# Altium schematic sheet Audio.SchDoc(Audio) (continued)

## Library data of the parts
C6 = R82DC3220AA60K: Film Capacitor, 0.22 uF, 40 V, 63 V, ± 10%, Metallized PET Stacked, Radial Box - 2 Pin; Component Kind: Standard; Library Name: Fuzz_Pedal_Lib.SchLib
C7 C8 = R82EC2560SH60J: 0.056 µF Film Capacitor 63V 100V Polyester, Polyethylene Terephthalate (PET), Metallized - Stacked Radial; Manufacturer: Yageo Group; Dissipation Factor: 80%; Lead Diameter: 500µm; Tolerance: 5%; Type: General Purpose; Value: 56nF; Voltage Rating (AC): 40V; Case/Package: Radial; Category: Film Capacitors; Component Kind: Standard; ComponentLink1Description: https://datasheet.octopart.com:443/R82DC3220AA60K-KEMET-datasheet-182036371.pdf?src-supplier=IHS; ComponentLink1URL: https://datasheet.octopart.com:443/R82DC3220AA60K-KEMET-datasheet-182036371.pdf?src-supplier=IHS; ComponentLink2Description: https://datasheet.octopart.com:443/R82DC3220AA60K-KEMET-datasheet-83037517.pdf?src-supplier=Newark; ComponentLink2URL: https://datasheet.octopart.com:443/R82DC3220AA60K-KEMET-datasheet-83037517.pdf?src-supplier=Newark; ComponentLink3Description: https://datasheet.octopart.com:443/R82DC3220AA60K-KEMET-datasheet-176335909.pdf?src-supplier=Newark; ComponentLink3URL: https://datasheet.octopart.com:443/R82DC3220AA60K-KEMET-datasheet-176335909.pdf?src-supplier=Newark; ComponentLink4Description: https://datasheet.octopart.com:443/R82DC3220AA60K-KEMET-datasheet-137794307.pdf?src-supplier=Newark; ComponentLink4URL: https://datasheet.octopart.com:443/R82DC3220AA60K-KEMET-datasheet-137794307.pdf?src-supplier=Newark; ComponentLink5Description: https://datasheet.octopart.com:443/R82DC3220AA60K-KEMET-datasheet-181970268.pdf?src-supplier=Farnell; ComponentLink5URL: https://datasheet.octopart.com:443/R82DC3220AA60K-KEMET-datasheet-181970268.pdf?src-supplier=Farnell; ComponentLink6Description: https://datasheet.octopart.com:443/R82DC3220AA60K-KEMET-datasheet-98397505.pdf?src-supplier=element14+APAC; ComponentLink6URL: https://datasheet.octopart.com:443/R82DC3220AA60K-KEMET-datasheet-98397505.pdf?src-supplier=element14+APAC; ComponentLink7Description: https://datasheet.octopart.com:443/R82DC3220AA60K-KEMET-datasheet-86450218.pdf?src-supplier=element14+APAC; ComponentLink7URL: https://datasheet.octopart.com:443/R82DC3220AA60K-KEMET-datasheet-86450218.pdf?src-supplier=element14+APAC; ComponentLink8Description: https://datasheet.octopart.com:443/R82DC3220AA60K-KEMET-datasheet-189767587.pdf?src-supplier=ODG+(Origin+Data+Global); ComponentLink8URL: https://datasheet.octopart.com:443/R82DC3220AA60K-KEMET-datasheet-189767587.pdf?src-supplier=ODG+(Origin+Data+Global); ComponentLink9Description: https://datasheet.octopart.com:443/R82DC3220AA60K-KEMET-datasheet-157246254.pdf?src-supplier=JRH+Electronics; ComponentLink9URL: https://datasheet.octopart.com:443/R82DC3220AA60K-KEMET-datasheet-157246254.pdf?src-supplier=JRH+Electronics; ComponentLink10Description: https://datasheet.octopart.com:443/R82DC3220AA60K-KEMET-datasheet-117811300.pdf?src-supplier=TME; ComponentLink10URL: https://datasheet.octopart.com:443/R82DC3220AA60K-KEMET-datasheet-117811300.pdf?src-supplier=TME; ComponentLink11Description: https://datasheet.octopart.com:443/R82DC3220AA60K-KEMET-datasheet-62346237.pdf?src-supplier=TME; ComponentLink11URL: https://datasheet.octopart.com:443/R82DC3220AA60K-KEMET-datasheet-62346237.pdf?src-supplier=TME; ComponentLink12Description: http://sigma.octopart.com:80/conflict_mineral_statement/Yageo-company-3.pdf?src-supplier=Compliance+Statements; ComponentLink12URL: http://sigma.octopart.com:80/conflict_mineral_statement/Yageo-company-3.pdf?src-supplier=Compliance+Statements; ComponentLink13Description: http://sigma.octopart.com:80/rohs_statement/Yageo-company-2.pdf?src-supplier=Compliance+Statements; ComponentLink13URL: http://sigma.octopart.com:80/rohs_statement/Yageo-company-2.pdf?src-supplier=Compliance+Statements; Country of Origin: Mainland China, Taiwan; Depth: 2.5mm; Dielectric: PET; Dielectric Material: Polyester; Export Control Classification Number (ECCN) Code: EAR99; Harmonized Tariff Schedule (HTS) Code: 8532.25.00.70; Height: 6.5mm; Height - Seated (Max): 6.5024mm; Introduction Date: 2010-04-16; Lead Pitch: 5mm; Length: 7.2mm; Lifecycle Status: Production; Manufacturer Part Number: R82EC2560SH60J; Max Operating Temperature: 105°C; Min Operating Temperature: -55°C; Mounting Technology: Through Hole; Number of Terminals: 2; Packaging: Bulk; Pricing: 1=0.43, 10=0.262, 50=0.1946, 100=0.1738, 500=0.13882, 1000=0.12802 (USD); Radiation Hardening: No; Ratings: AEC-Q200; REACH SVHC: Unaffected; RoHS: Compliant; Stock: 3589; Supplier: DigiKey; Supplier Part Number: 399-R82EC2560SH60JCT-ND; Terminal Pitch: 5mm; Termination: Radial; Voltage Rating: 100V; Voltage Rating (DC): 100V; Width: 2.5mm; Library Name: Fuzz_Pedal_Lib.SchLib
C9 = UES1H330MPM1TD: Aluminum Electrolytic Capacitor, Non-polarized, Aluminum (wet), 50V, 20% +Tol, 20% -Tol, 33uF; Manufacturer: Nichicon; Life (Hours): 1000hours; Value: 33µF; Case/Package: Radial; Category: Aluminum Electrolytic Capacitors; China RoHS: Compliant; Component Kind: Standard; ComponentLink1Description: https://datasheet.octopart.com:443/UES1H330MPM1TD-Nichicon-datasheet-145428947.pdf?src-supplier=IHS; ComponentLink1URL: https://datasheet.octopart.com:443/UES1H330MPM1TD-Nichicon-datasheet-145428947.pdf?src-supplier=IHS; Country of Origin: Japan; Diameter: 10mm; Export Control Classification Number (ECCN) Code: EAR99; Harmonized Tariff Schedule (HTS) Code: 8532.22.00; Height - Seated (Max): 17.4752mm; Introduction Date: 2010-08-27; Lead Pitch: 5.0038mm; Length: 16mm; Lifecycle Status: Production; Manufacturer Part Number: UES1H330MPM1TD; Max Operating Temperature: 85°C; Min Operating Temperature: -40°C; Mounting Technology: Through Hole; Number of Terminals: 2; Pricing: 1=1.03, 10=0.655, 50=0.4964, 100=0.4462 (USD); REACH SVHC: Affected; RoHS: Compliant; Stock: 33; Supplier: DigiKey; Supplier Part Number: 493-10840-1-ND; Terminal Pitch: 5mm; Termination: Radial; Tolerance: 20%; Voltage Rating: 50V; Voltage Rating (DC): 50V; Library Name: Fuzz_Pedal_Lib.SchLib
C10 = UFW1V471MPD1TD: Radial Can ALUMINUM ELECTROLYTIC CAPACITOR UFW 35V 470muF ±20% 0.394Dia 10.00mm; Manufacturer: Nichicon; Leakage Current: 493.5µA; Life (Hours): 2000hours; Ripple Current: 630mA; Value: 470µF; Case/Package: Radial; Category: Aluminum Electrolytic Capacitors; China RoHS: Compliant; Component Kind: Standard; ComponentLink1Description: https://datasheet.octopart.com:443/UFW1V471MPD1TD-Nichicon-datasheet-137916677.pdf?src-supplier=IHS; ComponentLink1URL: https://datasheet.octopart.com:443/UFW1V471MPD1TD-Nichicon-datasheet-137916677.pdf?src-supplier=IHS; Diameter: 10mm; Height - Seated (Max): 17.4752mm; Lead Pitch: 5.0038mm; Length: 16mm; Lifecycle Status: Production; Manufacturer Part Number: UFW1V471MPD1TD; Max Operating Temperature: 85°C; Min Operating Temperature: -40°C; Mounting Technology: Through Hole; Number of Terminals: 2; Pricing: 1=0.89, 10=0.56, 50=0.4224, 100=0.3786 (USD); REACH SVHC: Affected; RoHS: Compliant; Schedule B: 8532220020, 8532220020|8532220020|8532220020|8532220020|8532220020; Stock: 110; Supplier: DigiKey; Supplier Part Number: 493-11045-1-ND; Terminal Pitch: 5mm; Tolerance: 20%; Voltage Rating: 35V; Voltage Rating (DC): 35V; Library Name: Fuzz_Pedal_Lib.SchLib
C11 = UFW1E221MPD1TD: Radial Can ALUMINUM ELECTROLYTIC CAPACITOR UFW 25V 220muF ±20% 0.315Dia 8.00mm; Manufacturer: Nichicon; Leakage Current: 165µA; Life (Hours): 2000hours; Ripple Current: 320mA; Value: 220µF; Case/Package: Radial; Category: Aluminum Electrolytic Capacitors; China RoHS: Compliant; Component Kind: Standard; ComponentLink1Description: https://datasheet.octopart.com:443/UFW1E221MPD1TD-Nichicon-datasheet-137916677.pdf?src-supplier=IHS; ComponentLink1URL: https://datasheet.octopart.com:443/UFW1E221MPD1TD-Nichicon-datasheet-137916677.pdf?src-supplier=IHS; ComponentLink2Description: https://datasheet.octopart.com:443/UFW1E221MPD1TD-Nichicon-datasheet-103276388.pdf?src-supplier=TME; ComponentLink2URL: https://datasheet.octopart.com:443/UFW1E221MPD1TD-Nichicon-datasheet-103276388.pdf?src-supplier=TME; Diameter: 8mm; Height - Seated (Max): 13.0048mm; Lead Pitch: 3.5052mm; Length: 11.5mm; Lifecycle Status: Production; Manufacturer Part Number: UFW1E221MPD1TD; Max Operating Temperature: 85°C; Min Operating Temperature: -40°C; Mounting Technology: Through Hole; Number of Terminals: 2; Pricing: 1000=0.11063, 2000=0.1011, 3000=0.09629, 5000=0.09091, 7000=0.08776, 10000=0.08471 (USD); REACH SVHC: Affected; RoHS: Compliant; Stock: 18000; Supplier: DigiKey; Supplier Part Number: 493-10992-3-ND; Terminal Pitch: 3.5mm; Tolerance: 20%; Voltage Rating: 25V; Voltage Rating (DC): 25V; Library Name: Fuzz_Pedal_Lib.SchLib
C12 = UCS2G100MPD1TD: Radial Can ALUMINUM ELECTROLYTIC CAPACITOR UCS 400V 10muF ±20% 0.394Dia 10.00mm; Manufacturer: KYOCERA AVX; Lead Diameter: 600µm; Leakage Current: 260µA; Life (Hours): 8000hours; Polarity: Polar; Ripple Current: 175mA; Value: 10µF; Case/Package: Radial; Category: Aluminum Electrolytic Capacitors; China RoHS: Compliant; Component Kind: Standard; ComponentLink1Description: https://datasheet.octopart.com:443/UCS2G100MPD1TD-AVX-datasheet-27608240.pdf?src-supplier=Upverter; ComponentLink1URL: https://datasheet.octopart.com:443/UCS2G100MPD1TD-AVX-datasheet-27608240.pdf?src-supplier=Upverter; ComponentLink2Description: https://datasheet.octopart.com:443/UCS2G100MPD1TD-Nichicon-datasheet-182099829.pdf?src-supplier=IHS; ComponentLink2URL: https://datasheet.octopart.com:443/UCS2G100MPD1TD-Nichicon-datasheet-182099829.pdf?src-supplier=IHS; ComponentLink3Description: https://datasheet.octopart.com:443/UCS2G100MPD1TD-Nichicon-datasheet-190027489.pdf?src-supplier=Farnell; ComponentLink3URL: https://datasheet.octopart.com:443/UCS2G100MPD1TD-Nichicon-datasheet-190027489.pdf?src-supplier=Farnell; ComponentLink4Description: https://datasheet.octopart.com:443/UCS2G100MPD1TD-Nichicon-datasheet-169250459.pdf?src-supplier=Future+Electronics; ComponentLink4URL: https://datasheet.octopart.com:443/UCS2G100MPD1TD-Nichicon-datasheet-169250459.pdf?src-supplier=Future+Electronics; ComponentLink5Description: https://datasheet.octopart.com:443/UCS2G100MPD1TD-Nichicon-datasheet-159781669.pdf?src-supplier=Future+Electronics; ComponentLink5URL: https://datasheet.octopart.com:443/UCS2G100MPD1TD-Nichicon-datasheet-159781669.pdf?src-supplier=Future+Electronics; ComponentLink6Description: https://datasheet.octopart.com:443/UCS2G100MPD1TD-Nichicon-datasheet-12523411.pdf?src-supplier=Future+Electronics; ComponentLink6URL: https://datasheet.octopart.com:443/UCS2G100MPD1TD-Nichicon-datasheet-12523411.pdf?src-supplier=Future+Electronics; ComponentLink7Description: https://datasheet.octopart.com:443/UCS2G100MPD1TD-Nichicon-datasheet-138083818.pdf?src-supplier=ODG+(Origin+Data+Global); ComponentLink7URL: https://datasheet.octopart.com:443/UCS2G100MPD1TD-Nichicon-datasheet-138083818.pdf?src-supplier=ODG+(Origin+Data+Global); ComponentLink8Description: https://datasheet.octopart.com:443/UCS2G100MPD1TD-Nichicon-datasheet-101168864.pdf?src-supplier=TME; ComponentLink8URL: https://datasheet.octopart.com:443/UCS2G100MPD1TD-Nichicon-datasheet-101168864.pdf?src-supplier=TME; ComponentLink9Description: https://datasheet.octopart.com:443/UCS2G100MPD1TD-Nichicon-datasheet-62308058.pdf?src-supplier=HQonline; ComponentLink9URL: https://datasheet.octopart.com:443/UCS2G100MPD1TD-Nichicon-datasheet-62308058.pdf?src-supplier=HQonline; ComponentLink10Description: http://sigma.octopart.com:80/conflict_mineral_statement/AVX-company-34.pdf?src-supplier=Compliance+Statements; ComponentLink10URL: http://sigma.octopart.com:80/conflict_mineral_statement/AVX-company-34.pdf?src-supplier=Compliance+Statements; ComponentLink11Description: http://sigma.octopart.com:80/reach_statement/AVX-company-19.pdf?src-supplier=Compliance+Statements; ComponentLink11URL: http://sigma.octopart.com:80/reach_statement/AVX-company-19.pdf?src-supplier=Compliance+Statements; Country of Origin: Japan; Diameter: 10mm; Export Control Classification Number (ECCN) Code: EAR99; Features: General Purpose; Harmonized Tariff Schedule (HTS) Code: 8532.22.00; Height - Seated (Max): 21.9964mm; Introduction Date: 2010-08-27; Lead Free: Lead Free; Lead Pitch: 5mm; Length: 20mm; Lifecycle Status: Production; Manufacturer Part Number: UCS2G100MPD1TD; Max Operating Temperature: 105°C; Min Operating Temperature: -40°C; Mounting Technology: Through Hole; Number of Terminals: 2; Pricing: 1=1.25, 10=0.797, 50=0.6072, 100=0.5471, 500=0.44366, 1000=0.41106, 1500=0.3946, 2500=0.37626, 3500=0.36547, 5000=0.35506 (USD); Radiation Hardening: No; REACH SVHC: Affected; RoHS: Compliant; Schedule B: 8532220020, 8532220020|8532220020|8532220020|8532220020|8532220020; Stock: 58653; Supplier: DigiKey; Supplier Part Number: 493-7383-1-ND; Terminal Pitch: 5mm; Termination: Radial; Tolerance: 20%; Voltage Rating: 400V; Voltage Rating (DC): 400V; Library Name: Fuzz_Pedal_Lib.SchLib
C13 C14 = C0603C104K5RACAUTO: Multilayer Ceramic Capacitors MLCC - SMD/SMT 50V 0.1uF X7R 0603 10% AEC-Q200; Manufacturer: Yageo Group; Dissipation Factor: 2.5%; Temperature Coefficient: 30ppm/°C; Value: 100nF; Case Code (Imperial): 0603; Case Code (Metric): 1608; Case/Package: 0603; Category: Ceramic Capacitors; China RoHS: Compliant; Component Kind: Standard; ComponentLink1Description: https://datasheet.octopart.com:443/C0603C104K5RACAUTO-KEMET-datasheet-178087915.pdf?src-supplier=Farnell; ComponentLink1URL: https://datasheet.octopart.com:443/C0603C104K5RACAUTO-KEMET-datasheet-178087915.pdf?src-supplier=Farnell; ComponentLink2Description: https://datasheet.octopart.com:443/C0603C104K5RACAUTO-KEMET-datasheet-140480346.pdf?src-supplier=Farnell; ComponentLink2URL: https://datasheet.octopart.com:443/C0603C104K5RACAUTO-KEMET-datasheet-140480346.pdf?src-supplier=Farnell; ComponentLink3Description: https://datasheet.octopart.com:443/C0603C104K5RACAUTO-KEMET-datasheet-138896278.pdf?src-supplier=Farnell; ComponentLink3URL: https://datasheet.octopart.com:443/C0603C104K5RACAUTO-KEMET-datasheet-138896278.pdf?src-supplier=Farnell; ComponentLink4Description: https://datasheet.octopart.com:443/C0603C104K5RACAUTO-KEMET-datasheet-101575653.pdf?src-supplier=Farnell; ComponentLink4URL: https://datasheet.octopart.com:443/C0603C104K5RACAUTO-KEMET-datasheet-101575653.pdf?src-supplier=Farnell; ComponentLink5Description: https://datasheet.octopart.com:443/C0603C104K5RACAUTO-KEMET-datasheet-169773475.pdf?src-supplier=Farnell; ComponentLink5URL: https://datasheet.octopart.com:443/C0603C104K5RACAUTO-KEMET-datasheet-169773475.pdf?src-supplier=Farnell; ComponentLink6Description: https://datasheet.octopart.com:443/C0603C104K5RAC-AUTO-Kemet-datasheet-11517047.pdf?src-supplier=Farnell; ComponentLink6URL: https://datasheet.octopart.com:443/C0603C104K5RAC-AUTO-Kemet-datasheet-11517047.pdf?src-supplier=Farnell; ComponentLink7Description: https://datasheet.octopart.com:443/C0603C104K5RACAUTO-KEMET-datasheet-137357764.pdf?src-supplier=Upverter; ComponentLink7URL: https://datasheet.octopart.com:443/C0603C104K5RACAUTO-KEMET-datasheet-137357764.pdf?src-supplier=Upverter; ComponentLink8Description: https://datasheet.octopart.com:443/C0603C104K5RACAUTO-Kemet-datasheet-17277841.pdf?src-supplier=Newark; ComponentLink8URL: https://datasheet.octopart.com:443/C0603C104K5RACAUTO-Kemet-datasheet-17277841.pdf?src-supplier=Newark; ComponentLink9Description: https://datasheet.octopart.com:443/C0603C104K5RAC-AUTO-Kemet-datasheet-10850653.pdf?src-supplier=Newark; ComponentLink9URL: https://datasheet.octopart.com:443/C0603C104K5RAC-AUTO-Kemet-datasheet-10850653.pdf?src-supplier=Newark; ComponentLink10Description: https://datasheet.octopart.com:443/C0603C104K5RACAUTO-KEMET-datasheet-176289396.pdf?src-supplier=JRH+Electronics; ComponentLink10URL: https://datasheet.octopart.com:443/C0603C104K5RACAUTO-KEMET-datasheet-176289396.pdf?src-supplier=JRH+Electronics; ComponentLink11Description: https://datasheet.octopart.com:443/C0603C104K5RACAUTO-KEMET-datasheet-153410967.pdf?src-supplier=Electro+Sonic; ComponentLink11URL: https://datasheet.octopart.com:443/C0603C104K5RACAUTO-KEMET-datasheet-153410967.pdf?src-supplier=Electro+Sonic; ComponentLink12Description: https://datasheet.octopart.com:443/C0603C104K5RACAUTO-KEMET-datasheet-142166320.pdf?src-supplier=Electro+Sonic; ComponentLink12URL: https://datasheet.octopart.com:443/C0603C104K5RACAUTO-KEMET-datasheet-142166320.pdf?src-supplier=Electro+Sonic; ComponentLink13Description: https://datasheet.octopart.com:443/C0603C104K5RACAUTO-Kemet-datasheet-66076775.pdf?src-supplier=element14+APAC; ComponentLink13URL: https://datasheet.octopart.com:443/C0603C104K5RACAUTO-Kemet-datasheet-66076775.pdf?src-supplier=element14+APAC; ComponentLink14Description: https://datasheet.octopart.com:443/C0603C104K5RACAUTO-Kemet-datasheet-66204749.pdf?src-supplier=element14+APAC; ComponentLink14URL: https://datasheet.octopart.com:443/C0603C104K5RACAUTO-Kemet-datasheet-66204749.pdf?src-supplier=element14+APAC; ComponentLink15Description: https://datasheet.octopart.com:443/C0603C104K5RACAUTO-Kemet-datasheet-66534724.pdf?src-supplier=element14+APAC; ComponentLink15URL: https://datasheet.octopart.com:443/C0603C104K5RACAUTO-Kemet-datasheet-66534724.pdf?src-supplier=element14+APAC; ComponentLink16Description: https://datasheet.octopart.com:443/C0603C104K5RACAUTO-KEMET-datasheet-83968784.pdf?src-supplier=TME; ComponentLink16URL: https://datasheet.octopart.com:443/C0603C104K5RACAUTO-KEMET-datasheet-83968784.pdf?src-supplier=TME; ComponentLink17Description: https://datasheet.octopart.com:443/C0603C104K5RACAUTO-KEMET-datasheet-83186888.pdf?src-supplier=TME; ComponentLink17URL: https://datasheet.octopart.com:443/C0603C104K5RACAUTO-KEMET-datasheet-83186888.pdf?src-supplier=TME; ComponentLink18Description: https://datasheet.octopart.com/C0603C104K5RACAUTO-KEMET-datasheet-84169754.pdf; ComponentLink18URL: https://datasheet.octopart.com/C0603C104K5RACAUTO-KEMET-datasheet-84169754.pdf; ComponentLink19Description: https://datasheet.octopart.com:443/C0603C104K5RACAUTO-KEMET-datasheet-189767472.pdf?src-supplier=ODG+(Origin+Data+Global); ComponentLink19URL: https://datasheet.octopart.com:443/C0603C104K5RACAUTO-KEMET-datasheet-189767472.pdf?src-supplier=ODG+(Origin+Data+Global); ComponentLink20Description: http://sigma.octopart.com:80/conflict_mineral_statement/Yageo-company-3.pdf?src-supplier=Compliance+Statements; ComponentLink20URL: http://sigma.octopart.com:80/conflict_mineral_statement/Yageo-company-3.pdf?src-supplier=Compliance+Statements; ComponentLink21Description: http://sigma.octopart.com:80/rohs_statement/Yageo-company-2.pdf?src-supplier=Compliance+Statements; ComponentLink21URL: http://sigma.octopart.com:80/rohs_statement/Yageo-company-2.pdf?src-supplier=Compliance+Statements; Contact Plating: Tin; Country of Origin: Mexico; Depth: 800µm; Dielectric: X7R; Dielectric Material: Ceramic; Export Control Classification Number (ECCN) Code: EAR99; Harmonized Tariff Schedule (HTS) Code: 8532.24.00.20; Height: 800µm; Introduction Date: 2011-07-27; Length: 1.6mm; Lifecycle Status: Production; Manufacturer Part Number: C0603C104K5RACAUTO; Material: Ceramic; Max Operating Temperature: 125°C; Min Operating Temperature: -55°C; Mounting Technology: Surface Mount; Number of Terminals: 2; Packaging: Cut Tape; Pricing: 1=0.15, 10=0.083, 50=0.0574, 100=0.0497, 500=0.0367 (USD); Radiation Hardening: No; Ratings: AEC-Q200; REACH SVHC: Yes; RoHS: Compliant; Schedule B: 8532240020, 8532240020|8532240020, 8532240020|8532240020|8532240020, 8532240020|8532240020|8532240020|8532240020; Stock: 1408607; Supplier: DigiKey; Supplier Part Number: 399-6856-6-ND; Termination: SMD/SMT; Thickness: 863.6µm; Tolerance: 10%; Voltage Rating: 50V; Voltage Rating (DC): 50V; Width: 800µm; Library Name: Tremolo_Pedal.IntLib
J2 J3 = RA49B11: Conn 1/4\" Phone Jack F 2 POS 7.62mm Solder RA Thru-Hole 4 Terminal 1 Port; Manufacturer: Switchcraft; Gender: Female; Number of Poles: 2; Number of Ports: 1; Shielding: Unshielded; Category: Connectors; Color: Black; Component Kind: Standard; ComponentLink1Description: https://datasheet.octopart.com:443/RA49B11-Switchcraft-datasheet-13685843.pdf?src-supplier=Master+Electronics; ComponentLink1URL: https://datasheet.octopart.com:443/RA49B11-Switchcraft-datasheet-13685843.pdf?src-supplier=Master+Electronics; ComponentLink2Description: https://datasheet.octopart.com:443/RA49B11-Switchcraft-datasheet-13537009.pdf?src-supplier=Sager+Electronics; ComponentLink2URL: https://datasheet.octopart.com:443/RA49B11-Switchcraft-datasheet-13537009.pdf?src-supplier=Sager+Electronics; ComponentLink3Description: https://datasheet.octopart.com:443/RA49B11-Switchcraft-datasheet-76366.pdf?src-supplier=Mouser; ComponentLink3URL: https://datasheet.octopart.com:443/RA49B11-Switchcraft-datasheet-76366.pdf?src-supplier=Mouser; Contact Plating: Silver; Country of Origin: USA; Depth: 34.03mm; Export Control Classification Number (ECCN) Code: EAR99; Harmonized Tariff Schedule (HTS) Code: 8536.69.40.10; Housing Color: Black; Housing Material: Thermoplastic; Introduction Date: 1997-12-01; Lead Free: Lead Free; Length: 15.74mm; Lifecycle Status: Production; Manufacturer Part Number: RA49B11; Mounting Technology: Through Hole; Number of Positions: 2; Number of Terminals: 4; Orientation: Right Angle; Pitch: 7.62mm; Pricing: 1=4.46, 10=3.793, 25=3.5556, 50=3.3856, 100=3.2239, 300=2.98343, 500=2.87784, 1000=2.74056, 2500=2.56916 (USD); REACH SVHC: Yes; RoHS: Compliant; Stock: 436; Supplier: DigiKey; Supplier Part Number: SC2546-ND; Termination: Solder; Library Name: Tremolo_Pedal.IntLib
Q1 Q2 = MMBT2222ALT1G: Bipolar (BJT) Transistor NPN 40V 600mA 300MHz 225mW Surface Mount SOT-23-3 Tape & Reel; Manufacturer: onsemi; Manufacturer Lifecycle Status: ACTIVE; Collector Base Voltage (VCBO): 75V; Collector Emitter Saturation Voltage: 300mV; Element Configuration: Single; Emitter Base Voltage (VEBO): 6V; hFE Min: 75; Max Junction Temperature (Tj): 150°C; Max Power Dissipation: 300mW; Number of Elements: 1; Type: General Purpose; Case/Package: SOT-23; Category: BJTs; China RoHS: Compliant; Clock Frequency: 300MHz; Collector Emitter Breakdown Voltage: 40V; Collector Emitter Voltage (VCEO): 40V; Component Kind: Standard; ComponentLink1Description: https://datasheet.octopart.com:443/MMBT2222ALT1G-ON-Semiconductor-datasheet-610557.pdf?src-supplier=onsemi; ComponentLink1URL: https://datasheet.octopart.com:443/MMBT2222ALT1G-ON-Semiconductor-datasheet-610557.pdf?src-supplier=onsemi; ComponentLink2Description: https://datasheet.octopart.com:443/MMBT2222ALT1G-ON-Semiconductor-datasheet-8326918.pdf?src-supplier=onsemi; ComponentLink2URL: https://datasheet.octopart.com:443/MMBT2222ALT1G-ON-Semiconductor-datasheet-8326918.pdf?src-supplier=onsemi; ComponentLink3Description: https://datasheet.octopart.com:443/MMBT2222ALT1G-onsemi-datasheet-180963556.pdf?src-supplier=IHS; ComponentLink3URL: https://datasheet.octopart.com:443/MMBT2222ALT1G-onsemi-datasheet-180963556.pdf?src-supplier=IHS; ComponentLink4Description: https://datasheet.octopart.com:443/MMBT2222ALT1G-ON-Semiconductor-datasheet-93401943.pdf?src-supplier=Upverter; ComponentLink4URL: https://datasheet.octopart.com:443/MMBT2222ALT1G-ON-Semiconductor-datasheet-93401943.pdf?src-supplier=Upverter; ComponentLink5Description: https://sigma.octopart.com:443/138897241/technical_drawing/ON-Semiconductor-MMBT2222ALT1G.pdf?src-supplier=Upverter; ComponentLink5URL: https://sigma.octopart.com:443/138897241/technical_drawing/ON-Semiconductor-MMBT2222ALT1G.pdf?src-supplier=Upverter; ComponentLink6Description: https://datasheet.octopart.com:443/MMBT2222ALT1G-ON-Semiconductor-datasheet-11598741.pdf?src-supplier=Farnell; ComponentLink6URL: https://datasheet.octopart.com:443/MMBT2222ALT1G-ON-Semiconductor-datasheet-11598741.pdf?src-supplier=Farnell; ComponentLink7Description: https://datasheet.octopart.com:443/MMBT2222ALT1G-ON-Semiconductor-datasheet-155100582.pdf?src-supplier=TME; ComponentLink7URL: https://datasheet.octopart.com:443/MMBT2222ALT1G-ON-Semiconductor-datasheet-155100582.pdf?src-supplier=TME; ComponentLink8Description: https://datasheet.octopart.com:443/MMBT2222ALT1G-ON-Semiconductor-datasheet-9666439.pdf?src-supplier=RS+(Formerly+Allied+Electronics); ComponentLink8URL: https://datasheet.octopart.com:443/MMBT2222ALT1G-ON-Semiconductor-datasheet-9666439.pdf?src-supplier=RS+(Formerly+Allied+Electronics); ComponentLink9Description: http://sigma.octopart.com:80/150618391/rohs_statement/ON-Semiconductor-MMBT2222ALT1G.pdf?src-supplier=Compliance+Statements; ComponentLink9URL: http://sigma.octopart.com:80/150618391/rohs_statement/ON-Semiconductor-MMBT2222ALT1G.pdf?src-supplier=Compliance+Statements; ComponentLink10Description: http://sigma.octopart.com:80/reach_statement/ON-Semiconductor-company-79.pdf?src-supplier=Compliance+Statements; ComponentLink10URL: http://sigma.octopart.com:80/reach_statement/ON-Semiconductor-company-79.pdf?src-supplier=Compliance+Statements; ComponentLink11Description: http://sigma.octopart.com:80/rohs_statement/Fairchild-Semiconductor-company-11.pdf?src-supplier=Compliance+Statements; ComponentLink11URL: http://sigma.octopart.com:80/rohs_statement/Fairchild-Semiconductor-company-11.pdf?src-supplier=Compliance+Statements; ComponentLink12Description: http://sigma.octopart.com:80/rohs_statement/Fairchild-Semiconductor-company-10.pdf?src-supplier=Compliance+Statements; ComponentLink12URL: http://sigma.octopart.com:80/rohs_statement/Fairchild-Semiconductor-company-10.pdf?src-supplier=Compliance+Statements; ComponentLink13Description: http://sigma.octopart.com:80/reach_statement/Fairchild-Semiconductor-company-9.pdf?src-supplier=Compliance+Statements; ComponentLink13URL: http://sigma.octopart.com:80/reach_statement/Fairchild-Semiconductor-company-9.pdf?src-supplier=Compliance+Statements; ComponentLink14Description: https://octopart.com:443/opatz8j6/c1?t=qUfD7E2yMa3Yk1vLXuv8OyRrgB35oCXT9-4lZ8hWbx63qVKY6CZ5Wx2VuO9N1oPnQ-OFoqvKrlbsAQTZvNQspaV8PzM0KMZrM5qimrAS2Zvqv1Jmjhq1XNHbtRnsNKP4QJNWwAWuXHzspahX0GPiJWYED5gCsLAWVM_Us9JHtNxAPP0IoypvAlSchXMw0lNpAwxWQgbKGZRbXI3egbgLrDMNwqXcjYqK5Lts70q_IXAZE63Sk2NOAQAaUe72vfA1BkFyWuN3Pafh36sNqvi0mkmDOT1QicIxslPPZmZplA9bng580C9Wj3PNCWJxQeY76huV7G-1Ts4qzJ3fZbIoJFL71Wq9dv0nuozXNA_jig8&src-supplier=Compliance+Statements; ComponentLink14URL: https://octopart.com:443/opatz8j6/c1?t=qUfD7E2yMa3Yk1vLXuv8OyRrgB35oCXT9-4lZ8hWbx63qVKY6CZ5Wx2VuO9N1oPnQ-OFoqvKrlbsAQTZvNQspaV8PzM0KMZrM5qimrAS2Zvqv1Jmjhq1XNHbtRnsNKP4QJNWwAWuXHzspahX0GPiJWYED5gCsLAWVM_Us9JHtNxAPP0IoypvAlSchXMw0lNpAwxWQgbKGZRbXI3egbgLrDMNwqXcjYqK5Lts70q_IXAZE63Sk2NOAQAaUe72vfA1BkFyWuN3Pafh36sNqvi0mkmDOT1QicIxslPPZmZplA9bng580C9Wj3PNCWJxQeY76huV7G-1Ts4qzJ3fZbIoJFL71Wq9dv0nuozXNA_jig8&src-supplier=Compliance+Statements; ComponentLink15Description: https://octopart.com:443/opatz8j6/c1?t=kIt2DkC0MAyf1TCB62HN4xrKGgEtOl4LXqimdH22cZ_WyAC3wC3E4Hdpe_0KznFcpnPTUsRM23Gl7aUqdnmdck66MDx_v__wJydKmihBqdbws59vCRNJfil0wkQBEwEF0_4QxDPd5IBZVfNlTKO6LnTTXAfkmbX-sPSl7uFpYsmiM-Sa7nH5TCfNiTPGpSB6kRuHhRRQlxAWjs174o_s8ub9WNbbjmqKG85Y2S3lbzJ_5XqEIWEtZ9AAJuebf_DLORk6e_Bzf-XCemQihTbyzJJFMLlrj4SBSEfiYkGJOKdEc8sNzlylH9ez8k9mXm3ufCPCTItPmmbs2xTNOrcgSFHxJ3mw6Y0m7fPrd-6i5Jlh&src-supplier=Compliance+Statements; ComponentLink15URL: https://octopart.com:443/opatz8j6/c1?t=kIt2DkC0MAyf1TCB62HN4xrKGgEtOl4LXqimdH22cZ_WyAC3wC3E4Hdpe_0KznFcpnPTUsRM23Gl7aUqdnmdck66MDx_v__wJydKmihBqdbws59vCRNJfil0wkQBEwEF0_4QxDPd5IBZVfNlTKO6LnTTXAfkmbX-sPSl7uFpYsmiM-Sa7nH5TCfNiTPGpSB6kRuHhRRQlxAWjs174o_s8ub9WNbbjmqKG85Y2S3lbzJ_5XqEIWEtZ9AAJuebf_DLORk6e_Bzf-XCemQihTbyzJJFMLlrj4SBSEfiYkGJOKdEc8sNzlylH9ez8k9mXm3ufCPCTItPmmbs2xTNOrcgSFHxJ3mw6Y0m7fPrd-6i5Jlh&src-supplier=Compliance+Statements; ComponentLink16Description: https://octopart.com:443/opatz8j6/c1?t=RVBw5Uc_Pazp42tUvaXS935cT8-DxpHWeVIh4jXHYy4FesJzPPCIcMiqYsNP7NqI3m7N8TKf8Albb342jbgVNXmkWnUz6gHgxAhyOhAnnoxGWZ5PI8dlUF9cAioFHqWVOV_D_N8fBmxKyk3z8i0FyerI2MquCKm8Ln-q5fn8BS6QCo5pr23rcU5tsu1QR4Af6HD8BmMSt_8GMuyCwA2NkrD9fIVaHy3VtBAKDxaMVO6y7u7LpPWHctH9hE20XcPiOAaUdi-rW2B7fXh7GTWiQLP3gRv8gfdHIShjsrlWD-oME1xcy5ic1bClPT5U4eHZ9MSIKaRqPzisKfm__r5FQX-RXRc0UcKzTW2wqRtUdpg&src-supplier=Compliance+Statements; ComponentLink16URL: https://octopart.com:443/opatz8j6/c1?t=RVBw5Uc_Pazp42tUvaXS935cT8-DxpHWeVIh4jXHYy4FesJzPPCIcMiqYsNP7NqI3m7N8TKf8Albb342jbgVNXmkWnUz6gHgxAhyOhAnnoxGWZ5PI8dlUF9cAioFHqWVOV_D_N8fBmxKyk3z8i0FyerI2MquCKm8Ln-q5fn8BS6QCo5pr23rcU5tsu1QR4Af6HD8BmMSt_8GMuyCwA2NkrD9fIVaHy3VtBAKDxaMVO6y7u7LpPWHctH9hE20XcPiOAaUdi-rW2B7fXh7GTWiQLP3gRv8gfdHIShjsrlWD-oME1xcy5ic1bClPT5U4eHZ9MSIKaRqPzisKfm__r5FQX-RXRc0UcKzTW2wqRtUdpg&src-supplier=Compliance+Statements; Contact Plating: Tin; Country of Origin: Mainland China; Current Rating: 600mA; Export Control Classification Number (ECCN) Code: EAR99; Frequency: 300MHz; Gain Bandwidth Product: 300MHz; Height: 1.11mm; Introduction Date: 1999-01-01; Lead Free: Lead Free; Length: 2.9mm; Lifecycle Status: Production; Manufacturer Part Number: MMBT2222ALT1G; Max Breakdown Voltage: 40V; Max Collector Current: 600mA; Max Operating Temperature: 150°C; Min Operating Temperature: -55°C; Number of Terminals: 3; Packaging: Tape and Reel; Pins: 3; Polarity: NPN; Power Dissipation: 225mW; Pricing: 1=0.15, 10=0.091, 100=0.0559, 500=0.04042, 1000=0.03538 (USD); Radiation Hardening: No; REACH SVHC: Unaffected; RoHS: Compliant; Schedule B: 8541210080, 8541210080|8541210080|8541210080|8541210080|8541210080; Stock: 2015515; Supplier: DigiKey; Supplier Part Number: MMBT2222ALT1GOSDKR-ND; Transition Frequency: 300MHz; Voltage Rating (DC): 40V; Width: 1.3mm; Library Name: Tremolo_Pedal.IntLib
R5 = CRCW0805909RFKEA: Res Thick Film 0805 909 Ohm 1% 1/8W ±100ppm/°C Molded SMD SMD Paper T/R; Manufacturer: Vishay; Manufacturer Package Identifier: CRCW0805-e3; Max Power Dissipation: 125mW; Value: 909Ω; Voltage Rating: 150V; Case Code (Imperial): 0805; Case/Package: 0805; Category: Chip SMD Resistors; China RoHS: Non-Compliant; Component Kind: Standard; ComponentLink1Description: https://datasheet.octopart.com:443/CRCW0805909RFKEA-Vishay-datasheet-175423584.pdf?src-supplier=IHS; ComponentLink1URL: https://datasheet.octopart.com:443/CRCW0805909RFKEA-Vishay-datasheet-175423584.pdf?src-supplier=IHS; ComponentLink2Description: https://datasheet.octopart.com:443/CRCW0805909RFKEA-Vishay-datasheet-66077789.pdf?src-supplier=element14+APAC; ComponentLink2URL: https://datasheet.octopart.com:443/CRCW0805909RFKEA-Vishay-datasheet-66077789.pdf?src-supplier=element14+APAC; ComponentLink3Description: https://datasheet.octopart.com:443/CRCW0805909RFKEA-Vishay-datasheet-62317937.pdf?src-supplier=element14+APAC; ComponentLink3URL: https://datasheet.octopart.com:443/CRCW0805909RFKEA-Vishay-datasheet-62317937.pdf?src-supplier=element14+APAC; ComponentLink4Description: https://datasheet.octopart.com:443/CRCW0805909RFKEA.-Vishay-datasheet-83961561.pdf?src-supplier=element14+APAC; ComponentLink4URL: https://datasheet.octopart.com:443/CRCW0805909RFKEA.-Vishay-datasheet-83961561.pdf?src-supplier=element14+APAC; ComponentLink5Description: https://datasheet.octopart.com:443/CRCW0805909RFKEA.-Vishay-datasheet-78776209.pdf?src-supplier=element14+APAC; ComponentLink5URL: https://datasheet.octopart.com:443/CRCW0805909RFKEA.-Vishay-datasheet-78776209.pdf?src-supplier=element14+APAC; ComponentLink6Description: https://datasheet.octopart.com:443/CRCW0805909RFKEA-Vishay-datasheet-14722531.pdf?src-supplier=element14+APAC; ComponentLink6URL: https://datasheet.octopart.com:443/CRCW0805909RFKEA-Vishay-datasheet-14722531.pdf?src-supplier=element14+APAC; ComponentLink7Description: https://datasheet.octopart.com:443/CRCW0805909RFKEA-Vishay-datasheet-5299586.pdf?src-supplier=element14+APAC; ComponentLink7URL: https://datasheet.octopart.com:443/CRCW0805909RFKEA-Vishay-datasheet-5299586.pdf?src-supplier=element14+APAC; ComponentLink8Description: https://datasheet.octopart.com:443/CRCW0805909RFKEA-Vishay-datasheet-10380026.pdf?src-supplier=element14+APAC; ComponentLink8URL: https://datasheet.octopart.com:443/CRCW0805909RFKEA-Vishay-datasheet-10380026.pdf?src-supplier=element14+APAC; ComponentLink9Description: https://datasheet.octopart.com:443/CRCW0805909RFKEA-Vishay-datasheet-11761042.pdf?src-supplier=Newark; ComponentLink9URL: https://datasheet.octopart.com:443/CRCW0805909RFKEA-Vishay-datasheet-11761042.pdf?src-supplier=Newark; ComponentLink10Description: https://datasheet.octopart.com:443/CRCW0805909RFKEA-Vishay-datasheet-11516975.pdf?src-supplier=Newark; ComponentLink10URL: https://datasheet.octopart.com:443/CRCW0805909RFKEA-Vishay-datasheet-11516975.pdf?src-supplier=Newark; ComponentLink11Description: https://datasheet.octopart.com:443/CRCW0805909RFKEA-Vishay-datasheet-159614168.pdf?src-supplier=Newark; ComponentLink11URL: https://datasheet.octopart.com:443/CRCW0805909RFKEA-Vishay-datasheet-159614168.pdf?src-supplier=Newark; ComponentLink12Description: https://datasheet.octopart.com:443/CRCW0805909RFKEA-Vishay-datasheet-41302739.pdf?src-supplier=Newark; ComponentLink12URL: https://datasheet.octopart.com:443/CRCW0805909RFKEA-Vishay-datasheet-41302739.pdf?src-supplier=Newark; ComponentLink13Description: https://datasheet.octopart.com:443/CRCW0805909RFKEA-Vishay-datasheet-190005712.pdf?src-supplier=TME; ComponentLink13URL: https://datasheet.octopart.com:443/CRCW0805909RFKEA-Vishay-datasheet-190005712.pdf?src-supplier=TME; ComponentLink14Description: https://datasheet.octopart.com:443/CRCW0805909RFKEA-Vishay-datasheet-137115326.pdf?src-supplier=X-ON; ComponentLink14URL: https://datasheet.octopart.com:443/CRCW0805909RFKEA-Vishay-datasheet-137115326.pdf?src-supplier=X-ON; ComponentLink15Description: https://datasheet.octopart.com:443/CRCW0805909RFKEA-Vishay-datasheet-62316122.pdf?src-supplier=Future+Electronics; ComponentLink15URL: https://datasheet.octopart.com:443/CRCW0805909RFKEA-Vishay-datasheet-62316122.pdf?src-supplier=Future+Electronics; ComponentLink16Description: https://datasheet.octopart.com:443/CRCW0805909RFKEA-Vishay-datasheet-5300368.pdf?src-supplier=Future+Electronics; ComponentLink16URL: https://datasheet.octopart.com:443/CRCW0805909RFKEA-Vishay-datasheet-5300368.pdf?src-supplier=Future+Electronics; ComponentLink17Description: https://datasheet.octopart.com:443/CRCW0805909RFKEA-Vishay-Dale-datasheet-47688880.pdf?src-supplier=RS+(Formerly+Allied+Electronics); ComponentLink17URL: https://datasheet.octopart.com:443/CRCW0805909RFKEA-Vishay-Dale-datasheet-47688880.pdf?src-supplier=RS+(Formerly+Allied+Electronics); ComponentLink18Description: https://datasheet.octopart.com:443/CRCW0805909RFKEA-Vishay-datasheet-12001583.pdf?src-supplier=RS+(Formerly+Allied+Electronics); ComponentLink18URL: https://datasheet.octopart.com:443/CRCW0805909RFKEA-Vishay-datasheet-12001583.pdf?src-supplier=RS+(Formerly+Allied+Electronics); ComponentLink19Description: https://datasheet.octopart.com:443/CRCW0805909RFKEA-Vishay-datasheet-5306405.pdf?src-supplier=RS+(Formerly+Allied+Electronics); ComponentLink19URL: https://datasheet.octopart.com:443/CRCW0805909RFKEA-Vishay-datasheet-5306405.pdf?src-supplier=RS+(Formerly+Allied+Electronics); ComponentLink20Description: https://datasheet.octopart.com/D-CRCW-e3-Standard-Thick-Film-Chip-Resistors-Vishay-datasheet-family-64.pdf; ComponentLink20URL: https://datasheet.octopart.com/D-CRCW-e3-Standard-Thick-Film-Chip-Resistors-Vishay-datasheet-family-64.pdf; ComponentLink21Description: https://datasheet.octopart.com:443/CRCW0805909RFKEA-Vishay-datasheet-8445837.pdf?src-supplier=element14; ComponentLink21URL: https://datasheet.octopart.com:443/CRCW0805909RFKEA-Vishay-datasheet-8445837.pdf?src-supplier=element14; ComponentLink22Description: https://datasheet.octopart.com:443/CRCW0805909RFKEA-Vishay-datasheet-5377306.pdf?src-supplier=Garrett; ComponentLink22URL: https://datasheet.octopart.com:443/CRCW0805909RFKEA-Vishay-datasheet-5377306.pdf?src-supplier=Garrett; ComponentLink23Description: https://datasheet.octopart.com:443/CRCW0805909RFKEA-Vishay-datasheet-148188.pdf?src-supplier=Garrett; ComponentLink23URL: https://datasheet.octopart.com:443/CRCW0805909RFKEA-Vishay-datasheet-148188.pdf?src-supplier=Garrett; ComponentLink24Description: https://datasheet.octopart.com:443/CRCW0805909RFKEA-Vishay-datasheet-34203.pdf?src-supplier=Garrett; ComponentLink24URL: https://datasheet.octopart.com:443/CRCW0805909RFKEA-Vishay-datasheet-34203.pdf?src-supplier=Garrett; ComponentLink25Description: http://sigma.octopart.com:80/rohs_certificate/D-CRCW-e3-Standard-Thick-Film-Chip-Resistors-Vishay-family-102.pdf?src-supplier=Compliance+Statements; ComponentLink25URL: http://sigma.octopart.com:80/rohs_certificate/D-CRCW-e3-Standard-Thick-Film-Chip-Resistors-Vishay-family-102.pdf?src-supplier=Compliance+Statements; Composition: Thick Film; Contact Plating: Tin; Country of Origin: Israel; Depth: 1.25mm; Export Control Classification Number (ECCN) Code: EAR99; Features: Automotive AEC-Q200; Harmonized Tariff Schedule (HTS) Code: 8533.21.00.30; Height: 450µm; Height - Seated (Max): 508µm; Introduction Date: 2005-02-16; Lead Free: Lead Free; Length: 2mm; Lifecycle Status: Production; Manufacturer Part Number: CRCW0805909RFKEA; Max Operating Temperature: 155°C; Military Standard: Not; Min Operating Temperature: -55°C; Mounting Technology: Surface Mount; Number of Terminals: 2; Number of Terminations: 2; Pins: 2; Power Rating: 125mW; Pricing: 1=0.1, 10=0.044, 25=0.0332, 50=0.0272, 100=0.0225, 250=0.01796, 500=0.01534, 1000=0.01329 (USD); Radiation Hardening: No; Ratings: AEC-Q200; REACH SVHC: Unaffected; RoHS: Compliant; Schedule B: 8533210045, 8533210045|8533210045|8533210045|8533210045|8533210045|8533210045|8533210045|8533210045|8533210045|8533210045|8533210045|8533210045|8533210045|8533210045|8533210045|8533210045|8533210045|8533210045|8533210045|8533210045|8533210045|8533210045|8533210045|85; Stock: 25445; Supplier: DigiKey; Supplier Part Number: 541-909CCT-ND; Temperature Coefficient: 100ppm/°C; Termination: SMD/SMT; Tolerance: 1%; Voltage Rating (DC): 150V; Weight: 5.499807mg; Width: 1.25mm; Working Voltage: 150V; Library Name: Fuzz_Pedal_Lib.SchLib
R6 R9 R13 = RC0805FR-071ML: 125mW Thick Film Resistors 150V ±100ppm/℃ ±1% 1MΩ 0805 Chip Resistor - Surface Mount ROHS; Manufacturer: Yageo Group; Temperature Coefficient: 100ppm/°C; Case Code (Imperial): 0805; Case/Package: 0805; Category: Chip SMD Resistors; China RoHS: Non-Compliant; Component Kind: Standard; ComponentLink1Description: https://datasheet.octopart.com:443/RC0805FR-071ML-Yageo-datasheet-175887523.pdf?src-supplier=IHS; ComponentLink1URL: https://datasheet.octopart.com:443/RC0805FR-071ML-Yageo-datasheet-175887523.pdf?src-supplier=IHS; ComponentLink2Description: https://datasheet.octopart.com:443/RC0805FR-071ML-Yageo-datasheet-103092701.pdf?src-supplier=Upverter; ComponentLink2URL: https://datasheet.octopart.com:443/RC0805FR-071ML-Yageo-datasheet-103092701.pdf?src-supplier=Upverter; ComponentLink3Description: https://datasheet.octopart.com:443/RC0805FR-071ML-Yageo-datasheet-79472498.pdf?src-supplier=Upverter; ComponentLink3URL: https://datasheet.octopart.com:443/RC0805FR-071ML-Yageo-datasheet-79472498.pdf?src-supplier=Upverter; ComponentLink4Description: https://datasheet.octopart.com:443/RC0805FR-071ML-Yageo-datasheet-8330313.pdf?src-supplier=element14+APAC; ComponentLink4URL: https://datasheet.octopart.com:443/RC0805FR-071ML-Yageo-datasheet-8330313.pdf?src-supplier=element14+APAC; ComponentLink5Description: https://datasheet.octopart.com:443/RC0805FR-071ML-Yageo-Group-datasheet-190305687.pdf?src-supplier=Future+Electronics; ComponentLink5URL: https://datasheet.octopart.com:443/RC0805FR-071ML-Yageo-Group-datasheet-190305687.pdf?src-supplier=Future+Electronics; ComponentLink6Description: https://datasheet.octopart.com:443/RC0805FR-071ML-Yageo-datasheet-164759695.pdf?src-supplier=Future+Electronics; ComponentLink6URL: https://datasheet.octopart.com:443/RC0805FR-071ML-Yageo-datasheet-164759695.pdf?src-supplier=Future+Electronics; ComponentLink7Description: https://datasheet.octopart.com:443/RC0805FR-071ML-Yageo-datasheet-127823281.pdf?src-supplier=Future+Electronics; ComponentLink7URL: https://datasheet.octopart.com:443/RC0805FR-071ML-Yageo-datasheet-127823281.pdf?src-supplier=Future+Electronics; ComponentLink8Description: https://datasheet.octopart.com:443/RC0805FR-071ML-Yageo-datasheet-137700087.pdf?src-supplier=Future+Electronics; ComponentLink8URL: https://datasheet.octopart.com:443/RC0805FR-071ML-Yageo-datasheet-137700087.pdf?src-supplier=Future+Electronics; ComponentLink9Description: https://datasheet.octopart.com:443/RC0805FR-071ML-Yageo-datasheet-12592811.pdf?src-supplier=Future+Electronics; ComponentLink9URL: https://datasheet.octopart.com:443/RC0805FR-071ML-Yageo-datasheet-12592811.pdf?src-supplier=Future+Electronics; ComponentLink10Description: https://datasheet.octopart.com:443/RC0805FR-071ML-Yageo-datasheet-8324252.pdf?src-supplier=Future+Electronics; ComponentLink10URL: https://datasheet.octopart.com:443/RC0805FR-071ML-Yageo-datasheet-8324252.pdf?src-supplier=Future+Electronics; ComponentLink11Description: https://datasheet.octopart.com:443/RC0805FR-071ML-Yageo-datasheet-62067350.pdf?src-supplier=Farnell; ComponentLink11URL: https://datasheet.octopart.com:443/RC0805FR-071ML-Yageo-datasheet-62067350.pdf?src-supplier=Farnell; ComponentLink12Description: https://datasheet.octopart.com:443/RC0805FR-071ML-Yageo-datasheet-13691069.pdf?src-supplier=Farnell; ComponentLink12URL: https://datasheet.octopart.com:443/RC0805FR-071ML-Yageo-datasheet-13691069.pdf?src-supplier=Farnell; ComponentLink13Description: https://datasheet.octopart.com/RC0805FR-071ML-Yageo-datasheet-73766026.pdf; ComponentLink13URL: https://datasheet.octopart.com/RC0805FR-071ML-Yageo-datasheet-73766026.pdf; ComponentLink14Description: https://datasheet.octopart.com:443/RC0805FR-071ML-Yageo-datasheet-118657.pdf?src-supplier=DigiKey; ComponentLink14URL: https://datasheet.octopart.com:443/RC0805FR-071ML-Yageo-datasheet-118657.pdf?src-supplier=DigiKey; ComponentLink15Description: https://datasheet.octopart.com:443/RC0805FR-071ML-Yageo-datasheet-502840.pdf?src-supplier=element14; ComponentLink15URL: https://datasheet.octopart.com:443/RC0805FR-071ML-Yageo-datasheet-502840.pdf?src-supplier=element14; ComponentLink16Description: http://sigma.octopart.com:80/conflict_mineral_statement/Yageo-company-3.pdf?src-supplier=Compliance+Statements; ComponentLink16URL: http://sigma.octopart.com:80/conflict_mineral_statement/Yageo-company-3.pdf?src-supplier=Compliance+Statements; ComponentLink17Description: http://sigma.octopart.com:80/rohs_statement/Yageo-company-2.pdf?src-supplier=Compliance+Statements; ComponentLink17URL: http://sigma.octopart.com:80/rohs_statement/Yageo-company-2.pdf?src-supplier=Compliance+Statements; Composition: Thick Film; Contact Plating: Tin; Country of Origin: Mainland China, Taiwan; Depth: 1.25mm; Export Control Classification Number (ECCN) Code: EAR99; Features: Moisture Resistant; Harmonized Tariff Schedule (HTS) Code: 8533.21.00.30; Height: 500µm; Introduction Date: 2004-09-22; Lead Free: Lead Free; Length: 2mm; Lifecycle Status: Production; Manufacturer Part Number: RC0805FR-071ML; Max Operating Temperature: 155°C; Max Power Dissipation: 125mW; Military Standard: Not; Min Operating Temperature: -55°C; Mounting Technology: Surface Mount; Number of Terminals: 2; Number of Terminations: 2; Packaging: Tape & Reel; Pins: 2; Power Rating: 125mW; Pricing: 1=0.1, 10=0.034, 25=0.0256, 50=0.0208, 100=0.0171, 250=0.01344, 500=0.01136, 1000=0.00973 (USD); Radiation Hardening: No; REACH SVHC: Unaffected; RoHS: Compliant; Schedule B: 8533210045, 8533210045|8533210045, 8533210045|8533210045|8533210045, 8533210045|8533210045|8533210045|8533210045; Stock: 744844; Supplier: DigiKey; Supplier Part Number: 311-1.00MCRCT-ND; Termination: SMD/SMT; Tolerance: 1%; Value: 1MΩ; Voltage Rating: 150V; Voltage Rating (AC): 150V; Voltage Rating (DC): 150V; Width: 1.25mm; Working Voltage: 150V; Library Name: Fuzz_Pedal_Lib.SchLib
R7 = CRCW08057K32FKEA: Res Thick Film 0805 7.32K Ohm 1% 0.125W(1/8W) ±100ppm/C Pad SMD T/R Automotive AEC-Q200; Component Kind: Standard; Library Name: Fuzz_Pedal_Lib.SchLib
R8 = RT0805BRD075K9L: Fixed Resistor, Thin Film, 0.125W, 5900ohm, 150V, 0.1% +/-Tol, 25ppm/Cel, Surface Mount, 0805; Manufacturer: Yageo Group; Manufacturer Package Identifier: 0805 (2012 metric); Max Power Dissipation: 125mW; Temperature Coefficient: 25ppm/°C; Value: 5.9kΩ; Case Code (Imperial): 0805; Case/Package: 0805; Category: Chip SMD Resistors; China RoHS: Non-Compliant; Component Kind: Standard; ComponentLink1Description: https://datasheet.octopart.com:443/RT0805BRD075K9L-Yageo-datasheet-176402239.pdf?src-supplier=Future+Electronics; ComponentLink1URL: https://datasheet.octopart.com:443/RT0805BRD075K9L-Yageo-datasheet-176402239.pdf?src-supplier=Future+Electronics; ComponentLink2Description: https://datasheet.octopart.com:443/RT0805BRD075K9L-Yageo-datasheet-169971040.pdf?src-supplier=Future+Electronics; ComponentLink2URL: https://datasheet.octopart.com:443/RT0805BRD075K9L-Yageo-datasheet-169971040.pdf?src-supplier=Future+Electronics; ComponentLink3Description: https://datasheet.octopart.com:443/RT0805BRD075K9L-Yageo-datasheet-166250672.pdf?src-supplier=Future+Electronics; ComponentLink3URL: https://datasheet.octopart.com:443/RT0805BRD075K9L-Yageo-datasheet-166250672.pdf?src-supplier=Future+Electronics; ComponentLink4Description: https://datasheet.octopart.com:443/RT0805BRD075K9L-Yageo-datasheet-96443642.pdf?src-supplier=Upverter; ComponentLink4URL: https://datasheet.octopart.com:443/RT0805BRD075K9L-Yageo-datasheet-96443642.pdf?src-supplier=Upverter; ComponentLink5Description: https://datasheet.octopart.com:443/RT0805BRD075K9L-Yageo-Group-datasheet-188167888.pdf?src-supplier=LCSC; ComponentLink5URL: https://datasheet.octopart.com:443/RT0805BRD075K9L-Yageo-Group-datasheet-188167888.pdf?src-supplier=LCSC; ComponentLink6Description: https://datasheet.octopart.com:443/RT0805BRD075K9L-Yageo-Group-datasheet-141265893.pdf?src-supplier=ODG+(Origin+Data+Global); ComponentLink6URL: https://datasheet.octopart.com:443/RT0805BRD075K9L-Yageo-Group-datasheet-141265893.pdf?src-supplier=ODG+(Origin+Data+Global); ComponentLink7Description: https://datasheet.octopart.com/RT0805BRD075K9L-Yageo-datasheet-86813807.pdf; ComponentLink7URL: https://datasheet.octopart.com/RT0805BRD075K9L-Yageo-datasheet-86813807.pdf; ComponentLink8Description: http://sigma.octopart.com:80/conflict_mineral_statement/Yageo-company-3.pdf?src-supplier=Compliance+Statements; ComponentLink8URL: http://sigma.octopart.com:80/conflict_mineral_statement/Yageo-company-3.pdf?src-supplier=Compliance+Statements; ComponentLink9Description: http://sigma.octopart.com:80/rohs_statement/Yageo-company-2.pdf?src-supplier=Compliance+Statements; ComponentLink9URL: http://sigma.octopart.com:80/rohs_statement/Yageo-company-2.pdf?src-supplier=Compliance+Statements; Composition: Thin Film; Height: 500µm; Lead Free: Lead Free; Length: 2mm; Lifecycle Status: Production; Manufacturer Part Number: RT0805BRD075K9L; Max Operating Temperature: 155°C; Min Operating Temperature: -55°C; Mounting Technology: Surface Mount; Number of Terminals: 2; Number of Terminations: 2; Power Rating: 125mW; Pricing: 1=0.1, 10=0.083, 50=0.073, 100=0.069, 500=0.06062 (USD); REACH SVHC: Unaffected; RoHS: Compliant; Stock: 10212; Supplier: DigiKey; Supplier Part Number: YAG4926CT-ND; Termination: SMD/SMT; Tolerance: 0.1%; Voltage Rating: 150V; Voltage Rating (DC): 150V; Width: 1.25mm; Working Voltage: 150V; Library Name: Fuzz_Pedal_Lib.SchLib
